# Altium schematic sheet USBTOSERIAL-SCH.SchDoc
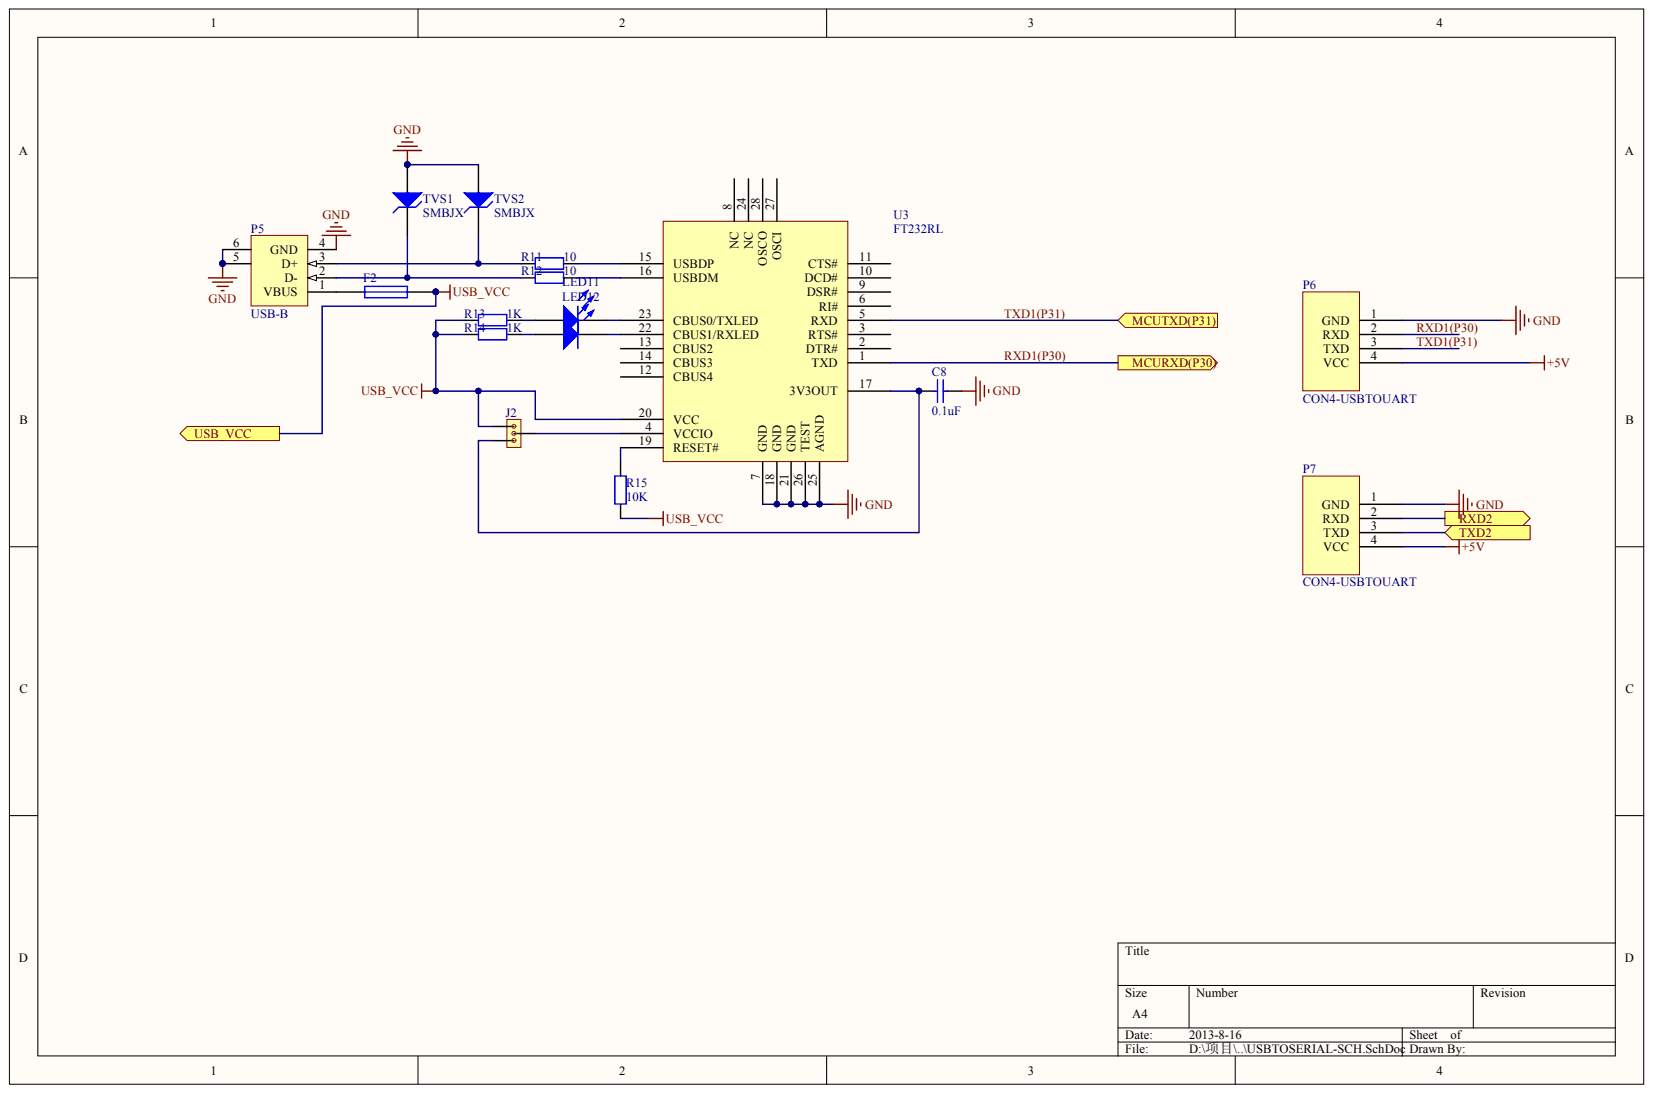
[diagram p0: USBTOSERIAL-SCH.SchDoc]

## Parts
C8  Cap 0805  (value not drawn)
F2  Fuse 1  (value not drawn)
J2  Jumper-3pin  (value not drawn)
LED11  LED_0805  (value not drawn)
LED12  LED_0805  (value not drawn)
P5  USB-B
P6  CON4-USBTOUART
P7  CON4-USBTOUART
R11  RES  (value not drawn)
R12  RES  (value not drawn)
R13  RES  (value not drawn)
R14  RES  (value not drawn)
R15  RES  (value not drawn)
TVS1  SMBJX
TVS2  SMBJX
U3  FT232RL

## Ports
MCURXD(P30), MCUTXD(P31), RXD2, TXD2, USB_VCC

## Nets
+5V: P6.4(VCC) P7.4(VCC)
GND: C8.2 P5.4(GND) P5.5 P5.6 P6.1(GND) P7.1(GND) TVS1.1 TVS2.1 U3.7(GND) U3.18(GND) U3.21(GND) U3.25(AGND) U3.26(TEST)
RXD1(P30): P6.2(RXD) U3.1(TXD) ; port MCURXD(P30)
RXD2: P7.2(RXD) ; port RXD2
TXD1(P31): P6.3(TXD) U3.5(RXD) ; port MCUTXD(P31)
TXD2: P7.3(TXD) ; port TXD2
USB_VCC: F2.2 J2.1 R13.1 R14.1 R15.1 U3.20(VCC) ; port USB_VCC
NetC8_1: C8.1 J2.3 U3.17(3V3OUT)
NetF2_1: F2.1 P5.1(VBUS)
NetJ2_2: J2.2 U3.4(VCCIO)
NetLED11_1: LED11.1 R13.2
NetLED11_2: LED11.2 U3.23(CBUS0/TXLED)
NetLED12_1: LED12.1 R14.2
NetLED12_2: LED12.2 U3.22(CBUS1/RXLED)
NetP5_2: P5.2(D-) R12.1 TVS1.2
NetP5_3: P5.3(D+) R11.1 TVS2.2
NetR11_2: R11.2 U3.15(USBDP)
NetR12_2: R12.2 U3.16(USBDM)
NetR15_2: R15.2 U3.19(RESET#)

Not connected: U3 2(DTR#) 3(RTS#) 6(RI#) 8(NC) 9(DSR#) 10(DCD#) 11(CTS#) 12(CBUS4) 13(CBUS2) 14(CBUS3) 24(NC) 27(OSCI) 28(OSCO)

## Library data of the parts
C8 F2 J2 LED11 LED12 P5-P7 R11-R15 TVS1 TVS2 U3 = (no symbol name)
  C8: : 0.1uF
  F2: Published: 8-Jun-2000; LatestRevisionDate: 17-Jul-2002; LatestRevisionNote: Re-released for DXP Platform.; PackageReference: PIN-W2/E2.8; Publisher: Altium Limited
  R11 R12: : 10
  R13 R14: : 1K
  R15: : 10K
